# Altium schematic sheet SoftOff.SchDoc
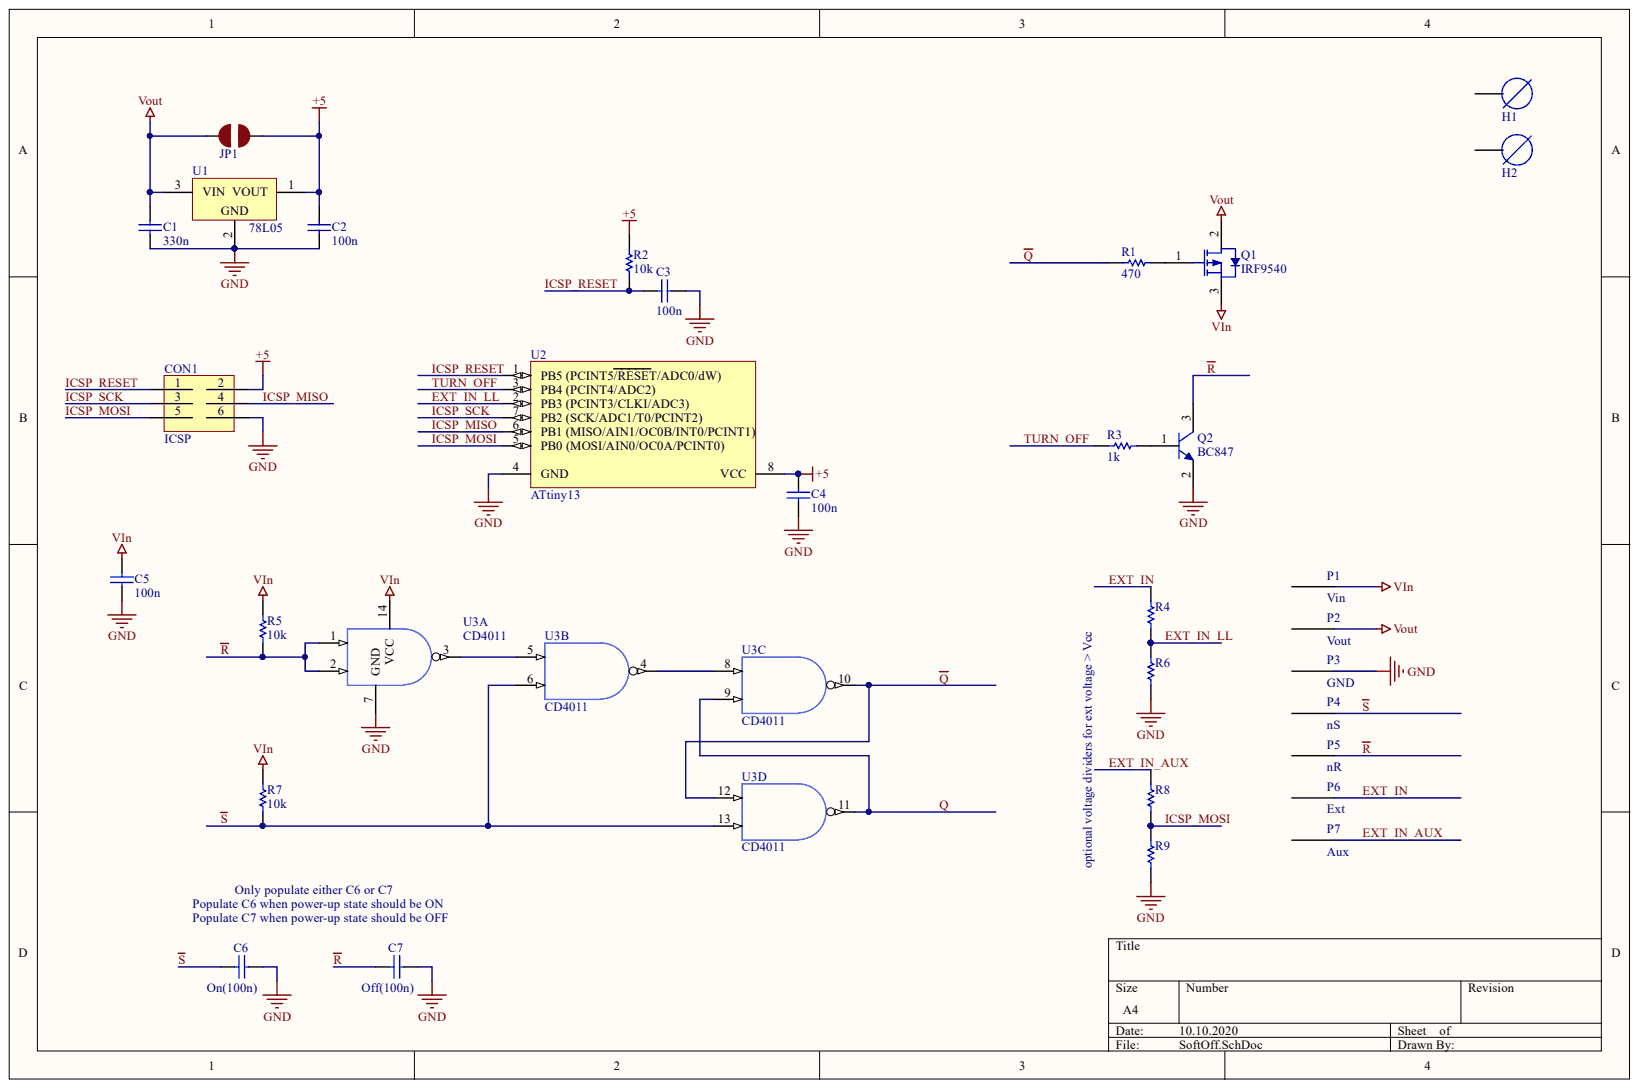
[diagram p0: SoftOff.SchDoc]

## Parts
C1  330n  [PCB-2cfusdo6sp8ecp4m660c-1]
C2  100n  [PCB-2cfusdo6sp8ecp4m660c-1]
C3  100n  [PCB-2cfusdo6sp8ecp4m660c-1]
C4  100n  [PCB-2cfusdo6sp8ecp4m660c-1]
C5  100n  [PCB-2cfusdo6sp8ecp4m660c-1]
C6  On(100n)  [PCB-2cfusdo6sp8ecp4m660c-1]
C7  Off(100n)  [PCB-2cfusdo6sp8ecp4m660c-1]
CON1  ICSP  [SIL-6]
H1  Drill Hole  [DRILL-M3-TIGHT]  (value not drawn)
H2  Drill Hole  [DRILL-M3-TIGHT]  (value not drawn)
JP1  Vin=Vcc  [PCB-eprq41etbehgejt90kdh-1]  (value not drawn)
P1  Vin  [PIN-100-PAD]
P2  Vout  [PIN-100-PAD]
P3  GND  [PIN-100-PAD]
P4  nS  [PIN-100-PAD]
P5  nR  [PIN-100-PAD]
P6  Ext  [PIN-100-PAD]
P7  Aux  [PIN-100-PAD]
Q1  IRF9540  [PCB-wj9t3cmlbvbw4cwyya3x-1]
Q2  BC847  [PCB-p8khi1w6gntrmsp9bbyg-1]
R1  470  [R0805-Medium]
R2  10k  [R0805-Medium]
R3  1k  [R0805-Medium]
R4  (no value)  [R0805-Medium]
R5  10k  [R0805-Medium]
R6  (no value)  [R0805-Medium]
R7  10k  [R0805-Medium]
R8  (no value)  [R0805-Medium]
R9  (no value)  [R0805-Medium]
U1  78L05  [TO-92]
U2  ATtiny13  [8S2_L]
U3  CD4011  [PCB-6ogfztztwrz5fzyqq6h5-1]  (parts A,B,C,D)

## Nets
+5: C2.2 C4.2 CON1.2 JP1.2 R2.2 U1.1 U2.8(VCC)
GND: C1.1 C2.1 C3.2 C4.1 C5.1 C6.2 C7.2 CON1.6 P3.1 Q2.2 R6.1 R9.1 U1.2 U2.4(GND) U3.7(GND)
VIN: C5.2 P1.1 Q1.3 R5.2 R7.2 U3.14(VCC)
VOUT: C1.2 JP1.1 P2.1 Q1.2 U1.3
EXT_IN: P6.1 R4.2
EXT_IN_AUX: P7.1 R8.2
EXT_IN_LL: R4.1 R6.2 U2.2(PB3_(PCINT3/CLKI/ADC3))
ICSP_MISO: CON1.4 U2.6(PB1_(MISO/AIN1/OC0B/INT0/PCINT1))
ICSP_MOSI: CON1.5 R8.1 R9.2 U2.5(PB0_(MOSI/AIN0/OC0A/PCINT0))
ICSP_RESET: C3.1 CON1.1 R2.1 U2.1(PB5_(PCINT5/RESET/ADC0/dW))
ICSP_SCK: CON1.3 U2.7(PB2_(SCK/ADC1/T0/PCINT2))
Q: U3.9 U3.11
~{Q}: R1.2 U3.10 U3.12
~{R}: C7.1 P5.1 Q2.3 R5.1 U3.1 U3.2
~{S}: C6.1 P4.1 R7.1 U3.6 U3.13
TURN_OFF: R3.2 U2.3(PB4_(PCINT4/ADC2))
NetQ1_1: Q1.1 R1.1
NetQ2_1: Q2.1 R3.1
NetU3_3: U3.3 U3.5
NetU3_4: U3.4 U3.8

Not connected: H1 1; H2 1

## Library data of the parts
C1-C5 C7 = 08051C104K4T2A: CAP CER 0.1UF 100V 10% X7R 0805; Capacitance: 1e-07 F; Voltage Rating (DC): 100.0 V; Capacitance Tolerance: ±10%; Case/Package: 0805; Component Kind: Standard; Library Name: Octopart library
C6 = CMP-126791-6: CAP CER 0.1UF 100V 10% X7R 0805; Capacitance: 1e-07 F; Voltage Rating (DC): 100.0 V; Capacitance Tolerance: ±10%; Case/Package: 0805; Component Kind: Standard; Library Name: CommunityVault
CON1 = CMP-uvra7lksyojs36dzyy1d-2: Connector with 6 pins; Component Kind: Standard; Library Name: CommunityVault
H1 H2 = Drill Hole-4: Drill hole with varying diameters; CustomComponentID: 6fg2s7uxucbqgec4pqmd; Component Kind: Standard; Library Name: FavoritesLibrary
JP1 = CMP-rlmbdwix7n8oyhujwj5s-3: Jumper; Component Kind: Standard; CustomComponentID: rlmbdwix7n8oyhujwj5s; Library Name: FavoritesLibrary
P1-P7 = CMP-iv9w4hav5xulhqaz2xo1-9: One-pin connector; Component Kind: Standard; Library Name: CommunityVault
Q1 = IRF9640PBF: MOSFET, Power; P-Ch; VDSS -200V; RDS(ON) 0.5Ohm; ID -11A; TO-220AB; PD 125W; VGS +/-20V; Continuous Drain Current (Ids): -11.0 A; Lead-Free Status: Lead Free; Polarity: P-Channel; Rise Time: 43.0 ns; Breakdown Voltage (Drain to Source): -200 V; Component Kind: Standard; Current Rating: -11.0 A; Drain to Source Voltage (Vds): -200 V; Library Name: Octopart library; Lifecycle Status: Not Listed by Manufacturer; Manufacturer Part Number: IRF9640PBF; Mounting Style: Through Hole; Number of Pins: 3; OctopartID: d40a1fc6f6cd0bcd; Octopart URL: http://octopart.com/irf9640pbf-vishay-46532513; Packaging: Tube; Power Dissipation: 125 W; REACH SVHC Compliance: No SVHC; RoHS: Compliant; Voltage Rating (DC): -200 V
Q2 = BC847C,215: BC847 series - 45 V, 100 mA NPN general-purpose transistors; Component Kind: Standard; Library Name: Octopart library
R1-R9 = CRGCQ0805J10K: Res Thick Film 0805 10K Ohm 5% 0.125W(1/8W) ±100ppm/C Pad SMD Automotive T/R; Resistance: 10.0 kΩ; Component Kind: Standard; Library Name: Octopart library; Manufacturer Part Number: CRGCQ0805J10K; Octopart URL: https://octopart.com/crgcq0805j10k-te+connectivity-91018201; RoHS: Compliant
U1 = CMP-1170740-1: Positive Voltage Regulator, 5V, 3-Pin TO-92; Dropout Voltage@Current (Typ): 1.7@40mA; Input Voltage: 30V; Line Regulation: 150mV; Load Regulation: 60mV; Number of Outputs: 1; Output Current: 100mA; Quiescent Current (Max): 6mA; Regulator Output Type: Fixed; Topology: Standard; CiivaID: 1170740; Ciiva URL: https://ciiva.com/part/L78L05ABZ-1170740; Component Kind: Standard; Library Name: CommunityVault; Mounting: Through Hole; Operating Temperature Classification: Automotive; Operating Temp Range: -40C to 125C; Output Voltage: 5V; Package Type: TO-92; Packaging: Bag; Pin Count: 3; Polarity Type: Positive; Voltage Regulator Type: Standard
U2 = ATTINY13V-10SU: 8-bit AVR Microcontroller, 1.8-5.5V, 1KB Flash, 64 Bytes EEPROM, 64 Bytes SRAM, 8-pin SOIC, Industrial Grade (-40°C to 85°C), Pb-Free; Access Time: 1e-05 s; Clock Speed: 10000000.0 Hz; FLASH Memory Size: 1024 B; Lead-Free Status: Lead Free; Number of Bits: 8; Number of I/O Pins: 6; RAM Memory Size: 64 B; Supply Voltage (DC): 5.0 V; Case/Package: SOIC; Component Kind: Standard; Core Architecture: AVR; Library Name: Octopart library; Manufacturer Part Number: ATTINY13V-10SU; Mounting Style: Surface Mount; Number of Pins: 8; OctopartID: dbf511b2bb4d0432; Octopart Url: https://octopart.com/attiny13v-10su-atmel-55395806; Packaging: Tube; REACH SVHC Compliance: No SVHC; RoHS: Compliant
U3 = CMP-37e2feef04ece825-6: CMOS Quad 2-Input NAND Gate SOIC-14 TSSOP-14 -55 to 125; Component Kind: Standard; Library Name: CommunityVault
